# Altium schematic sheet LEDeep_project.SchDoc
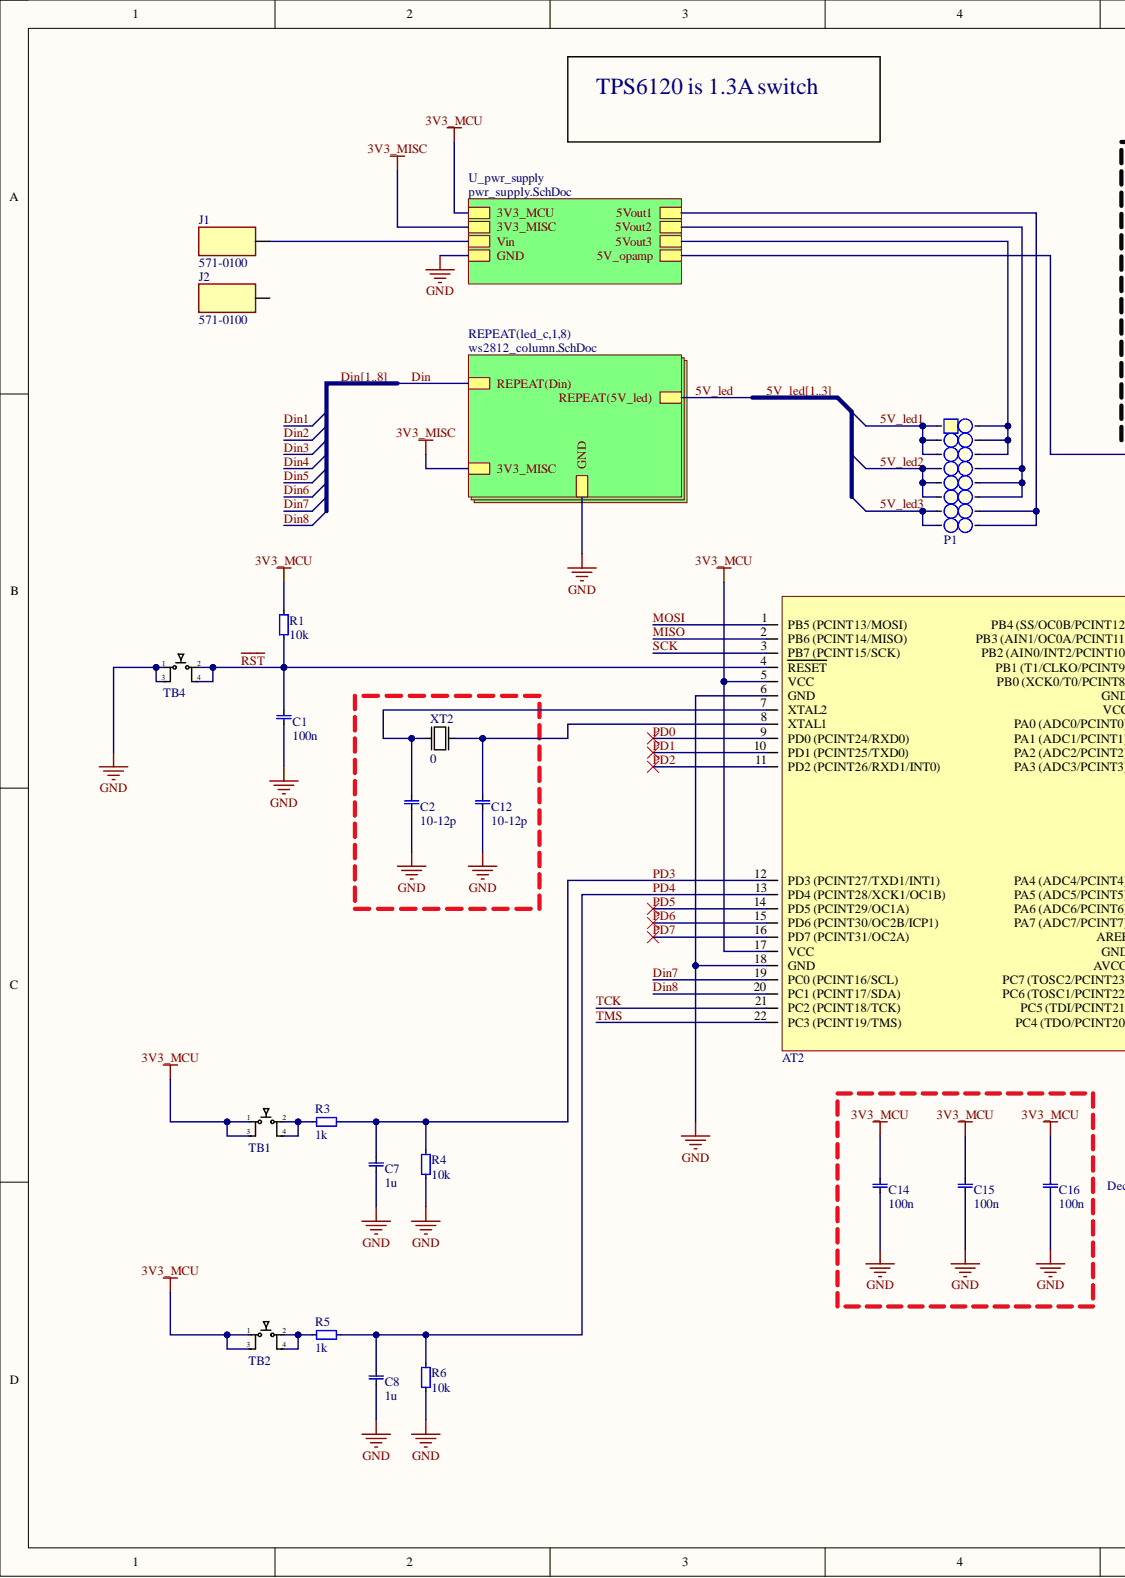
[diagram p0: LEDeep_project.SchDoc, left]
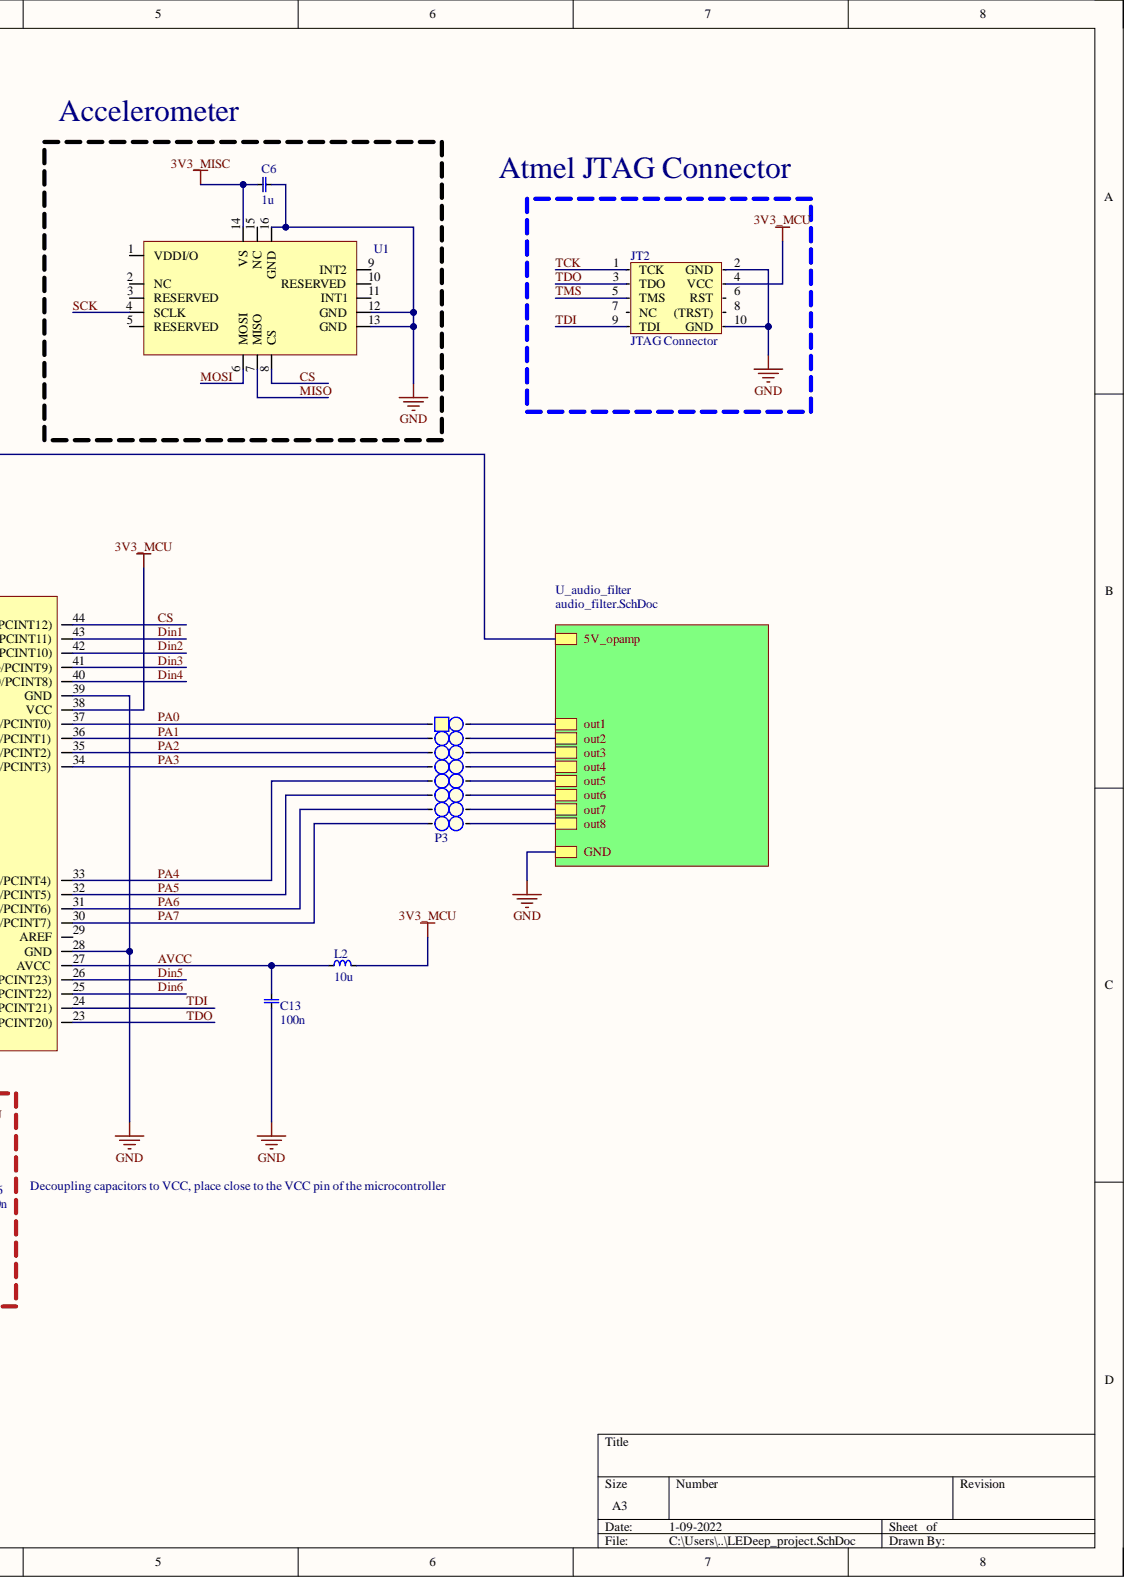
[diagram p1: LEDeep_project.SchDoc, right]

## Parts
AT2  ATmega644PA  [TQFP-44]  (value not drawn)
C1  value 100n  [1206, capasitor]  (hidden comment: 1206, capacitor)
C2  value 10-12p  [1206, capasitor]  (hidden comment: 1206, capacitor)
C6  value 1u  [1206, capasitor]  (hidden comment: 1206, capacitor)
C7  value 1u  [1206, capasitor]  (hidden comment: 1206, capacitor)
C8  value 1u  [1206, capasitor]  (hidden comment: 1206, capacitor)
C12  value 10-12p  [1206, capasitor]  (hidden comment: 1206, capacitor)
C13  value 100n  [1206, capasitor]  (hidden comment: 1206, capacitor)
C14  value 100n  [1206, capasitor]  (hidden comment: 1206, capacitor)
C15  value 100n  [1206, capasitor]  (hidden comment: 1206, capacitor)
C16  value 100n  [1206, capasitor]  (hidden comment: 1206, capacitor)
J1  571-0100  [Banana Conn VCC]
J2  571-0100  [Banana Conn VCC]
JT2  JTAG Connector  [Atmel_JTAG]
L2  value 10u  [1812, coil]  (hidden comment: Coil)
P1  2x8 Pin Header  [2x8 Pin Header]  (value not drawn)
P3  2x8 Pin Header  [2x8 Pin Header]  (value not drawn)
R1  value 10k  [1206]  (hidden comment: 1206, resistor)
R3  value 1k  [1206]  (hidden comment: 1206, resistor)
R4  value 10k  [1206]  (hidden comment: 1206, resistor)
R5  value 1k  [1206]  (hidden comment: 1206, resistor)
R6  value 10k  [1206]  (hidden comment: 1206, resistor)
TB1  Tactile Button  [Button smd]  (value not drawn)
TB2  Tactile Button  [Button smd]  (value not drawn)
TB4  Tactile Button  [Button smd]  (value not drawn)
U1  ADXL344  [lag_16_5by3_3x3mm]  (value not drawn)
XT2  value 0  [XTAL_SMD_LP]  (hidden comment: XTAL TXC 7A)

## Nets
3V3_MCU: AT2.5(VCC) AT2.17(VCC) AT2.38(VCC) C14.1 C15.1 C16.1 JT2.4(VCC) L2.1 R1.0 TB1.1 TB1.3 TB2.1 TB2.3
3V3_MISC: C6.0 U1.14(VS)
GND: AT2.6(GND) AT2.18(GND) AT2.28(GND) AT2.39(GND) C1.0 C2.0 C6.1 C7.0 C8.0 C12.0 C13.0 C14.0 C15.0 C16.0 JT2.2(GND) JT2.10(GND) R4.1 R6.1 TB4.1 TB4.3 U1.12(GND) U1.13(GND) U1.16(GND)
5V_led1: P1.1 P1.2 P1.3  [also labelled 5V_led]
5V_led2: P1.4 P1.5 P1.6  [also labelled 5V_led]
5V_led3: P1.7 P1.8  [also labelled 5V_led]
AVCC: AT2.27(AVCC) C13.1 L2.2
CS: AT2.44(PB4_(SS/OC0B/PCINT12)) U1.8(CS)
Din1: AT2.43(PB3_(AIN1/OC0A/PCINT11))  [also labelled Din]
Din2: AT2.42(PB2_(AIN0/INT2/PCINT10))  [also labelled Din]
Din3: AT2.41(PB1_(T1/CLKO/PCINT9))  [also labelled Din]
Din4: AT2.40(PB0_(XCK0/T0/PCINT8))  [also labelled Din]
Din5: AT2.26(PC7_(TOSC2/PCINT23))  [also labelled Din]
Din6: AT2.25(PC6_(TOSC1/PCINT22))  [also labelled Din]
Din7: AT2.19(PC0_(PCINT16/SCL))  [also labelled Din]
Din8: AT2.20(PC1_(PCINT17/SDA))  [also labelled Din]
MISO: AT2.2(PB6_(PCINT14/MISO)) U1.7(MISO)
MOSI: AT2.1(PB5_(PCINT13/MOSI)) U1.6(MOSI)
PA0: AT2.37(PA0_(ADC0/PCINT0)) P3.1
PA1: AT2.36(PA1_(ADC1/PCINT1)) P3.2
PA2: AT2.35(PA2_(ADC2/PCINT2)) P3.3
PA3: AT2.34(PA3_(ADC3/PCINT3)) P3.4
PA4: AT2.33(PA4_(ADC4/PCINT4)) P3.5
PA5: AT2.32(PA5_(ADC5/PCINT5)) P3.6
PA6: AT2.31(PA6_(ADC6/PCINT6)) P3.7
PA7: AT2.30(PA7_(ADC7/PCINT7)) P3.8
PD0: AT2.9(PD0_(PCINT24/RXD0))
PD1: AT2.10(PD1_(PCINT25/TXD0))
PD2: AT2.11(PD2_(PCINT26/RXD1/INT0))
PD3: AT2.12(PD3_(PCINT27/TXD1/INT1)) C7.1 R3.0 R4.0
PD4: AT2.13(PD4_(PCINT28/XCK1/OC1B)) C8.1 R5.0 R6.0
PD5: AT2.14(PD5_(PCINT29/OC1A))
PD6: AT2.15(PD6_(PCINT30/OC2B/ICP1))
PD7: AT2.16(PD7_(PCINT31/OC2A))
~{RST}: AT2.4(RESET) C1.1 R1.1 TB4.2 TB4.4
SCK: AT2.3(PB7_(PCINT15/SCK)) U1.4(SCLK)
TCK: AT2.21(PC2_(PCINT18/TCK)) JT2.1(TCK)
TDI: AT2.24(PC5_(TDI/PCINT21)) JT2.9(TDI)
TDO: AT2.23(PC4_(TDO/PCINT20)) JT2.3(TDO)
TMS: AT2.22(PC3_(PCINT19/TMS)) JT2.5(TMS)
NetAT2_7: AT2.7(XTAL2) C2.1 XT2.1
NetAT2_8: AT2.8(XTAL1) C12.1 XT2.2
NetAT2_29: AT2.29(AREF)
NetJ1_1: J1.1
NetJ2_1: J2.1
NetJT2_6: JT2.6(RST)
NetJT2_7: JT2.7(NC)
NetJT2_8: JT2.8((TRST))
NetP1_9: P1.9 P1.10
NetP1_11: P1.11 P1.12 P1.13
NetP1_14: P1.14 P1.15 P1.16
NetP3_9: P3.9
NetP3_10: P3.10
NetP3_11: P3.11
NetP3_12: P3.12
NetP3_13: P3.13
NetP3_14: P3.14
NetP3_15: P3.15
NetP3_16: P3.16
NetR3_1: R3.1 TB1.2 TB1.4
NetR5_1: R5.1 TB2.2 TB2.4
NetU1_1: U1.1(VDDI/O)
NetU1_2: U1.2(NC)
NetU1_3: U1.3(RESERVED)
NetU1_5: U1.5(RESERVED)
NetU1_9: U1.9(INT2)
NetU1_10: U1.10(RESERVED)
NetU1_11: U1.11(INT1)
NetU1_15: U1.15(NC)
5V_led4:
5V_led5:
5V_led6:
5V_led7:
5V_led8:
5V_opamp:

## Library data of the parts
AT2 = ATmega644PA: ATmega644PA - Small but big and PicoPower; Component Kind: Standard; Farnell: 2484915; Value: ATmega644PA; Library Name: eitp15_lab1_lib.IntLib
J1 J2 = Banana conn: Banana Connector 571-0100; Manufacturer_Name: Deltron; Component Kind: Standard; Datasheet Link: https://www.dem-uk.com/deltron-components/Data/Product_Downloads/deltron-571-0100-4mm-90deg-single-socket-data.pdf; Height: 12.4mm; Manufacturer_Part_Number: 571-0100; Mouser Part Number: 164-6218; Mouser Price/Stock: https://www.mouser.com/Search/Refine.aspx?Keyword=164-6218; Library Name: jclib.IntLib
JT2 = Atmel JTAG: Atmel JTAG Connector; Component Kind: Standard; Library Name: eitp15_lab1_lib.IntLib
P1 P3 = 2x8 Pin Header: 2.54 mm; Value: 1x8 Pin Header; Component Kind: Standard; Library Name: jclib.IntLib
R1 R3-R6 = 1206, resistor: Resistor; Component Kind: Standard; Library Name: eitp15_lab1_lib.IntLib
  R1 R4 R6: DigiKey: RMCF1206JT10K0CT-ND
  R3 R5: DigiKey: RPC1206JT1K00CT-ND
TB1 TB2 TB4 = Button SMD: Tactile Switch Small Low; Component Kind: Standard; ELFA: 110-87-406; Value: Button SMD; Library Name: eitp15_lab1_lib.IntLib
U1 = ADXL344: 3-Axis, ±2 g/±4 g/±8 g/±16 g Ultralow  Power Digital MEMS Accelerometer; Component Kind: Standard; DigiKey: ADXL344ACCZ-RL7; Library Name: adxl362.IntLib
XT2 = XTAL TXC 7A: 16 MHz, SMD, 5mm x 3,2mm, 30 ppm; Component Kind: Standard; Farnell: 1841946; Library Name: eitp15_lab1_lib.IntLib
C1 C2 C6-C8 C12-C16 = 1206, capacitor: Component Kind: Standard; Library Name: eitp15_lab1_lib.IntLib
  C1 C13-C16: DigiKey: 399-C1206C104M5RACAUTOCT-ND
  C2 C12: DigiKey: 399-C1206C100J5GAC7800CT-ND
  C6-C8: DigiKey: 1276-3298-1-ND
L2 = Coil: Component Kind: Standard; Library Name: eitp15_lab1_lib.IntLib
